# Altium schematic sheet 884-SG313_Potting_Controller.SchDoc
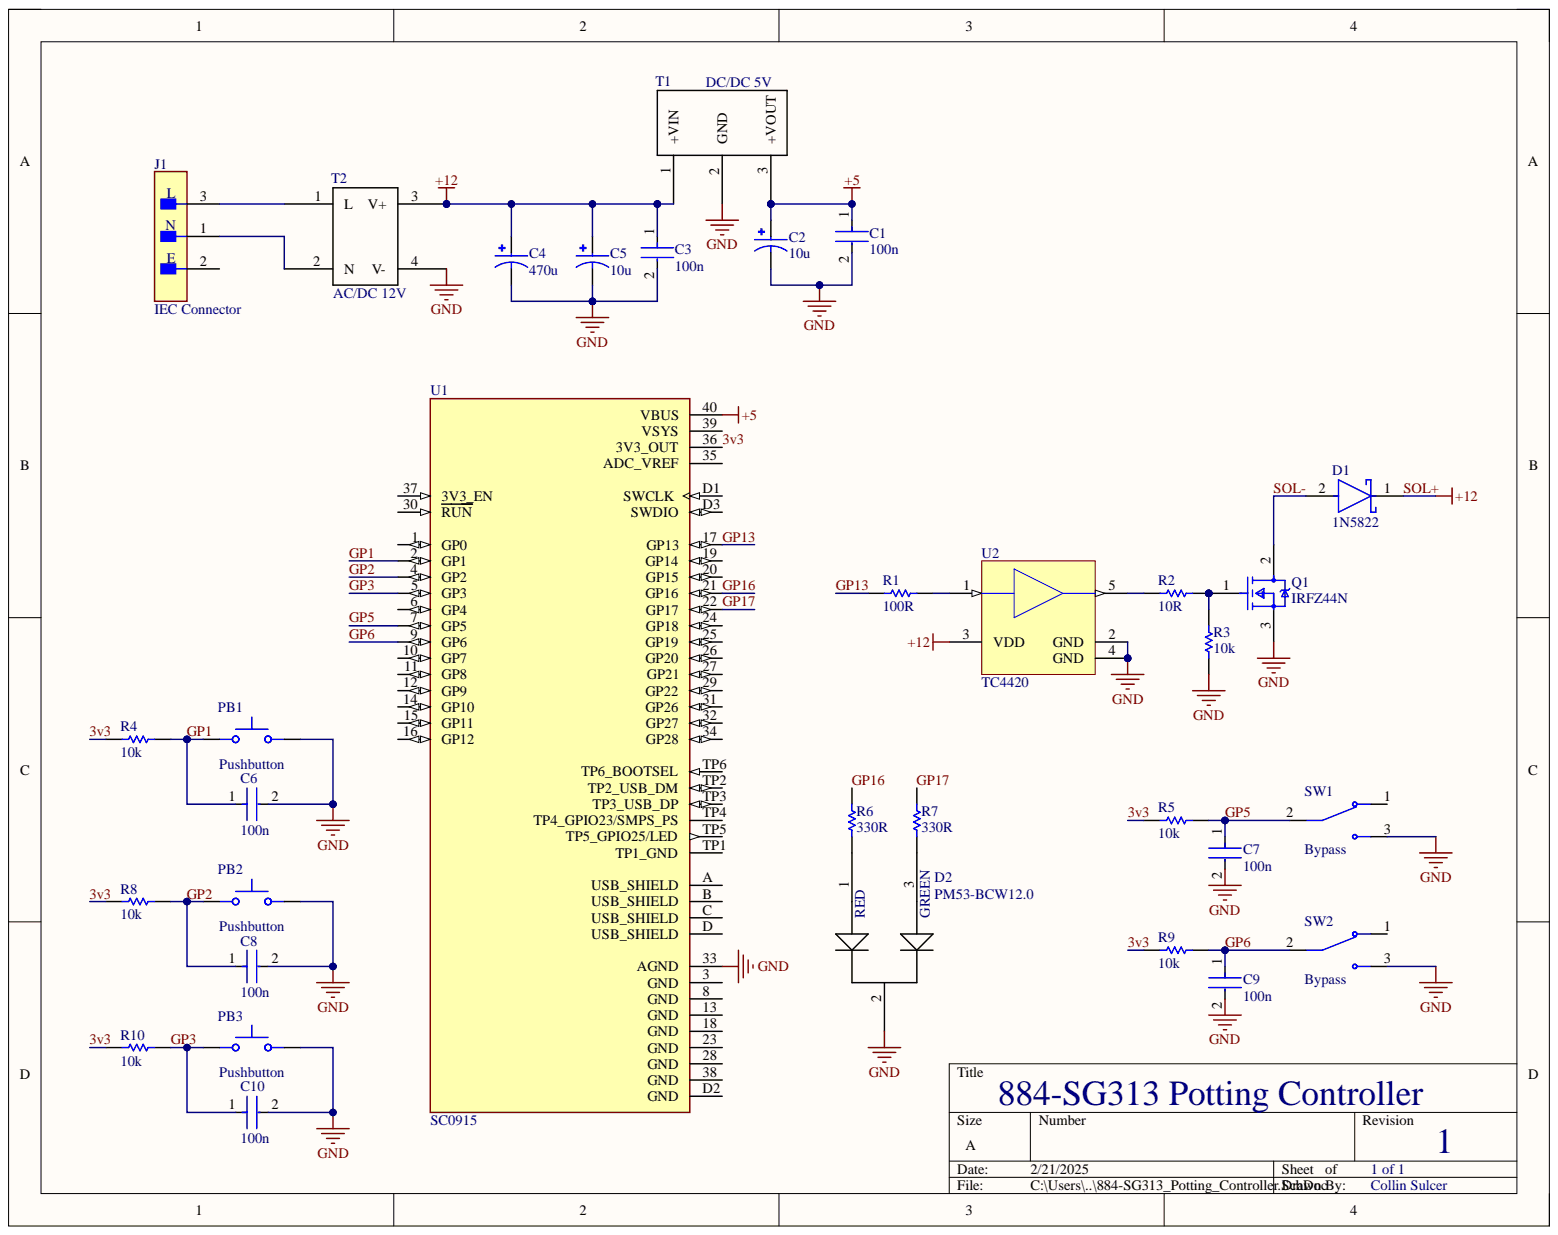
[diagram p0: 884-SG313_Potting_Controller.SchDoc]

## Parts
C1  100n  [FP-RDE1P1-MFG]
C2  10u  [CAPPRD500W45D630H500]
C3  100n  [FP-RDE1P1-MFG]
C4  470u  [CAPPRD500W45D630H500]
C5  10u  [CAPPRD500W45D630H500]
C6  100n  [FP-RDE1P1-MFG]
C7  100n  [FP-RDE1P1-MFG]
C8  100n  [FP-RDE1P1-MFG]
C9  100n  [FP-RDE1P1-MFG]
C10  100n  [FP-RDE1P1-MFG]
D1  1N5822  [FP-DO-201AD-MFG]
D2  PM53-BCW12.0
J1  IEC Connector  [FP-703W-00-52-MFG]
PB1  Pushbutton  [SW_800AWSP9M2QE]
PB2  Pushbutton  [SW_800AWSP9M2QE]
PB3  Pushbutton  [SW_800AWSP9M2QE]
Q1  IRFZ44N  [FP-PG-TO262-3-MFG]
R1  100R  [RESA60-630X240]
R2  10R  [RESA60-630X240]
R3  10k  [RESA60-630X240]
R4  10k  [RESA60-630X240]
R5  10k  [RESA60-630X240]
R6  330R  [RESA60-630X240]
R7  330R  [RESA60-630X240]
R8  10k  [RESA60-630X240]
R9  10k  [RESA60-630X240]
R10  10k  [RESA60-630X240]
SW1  Bypass  [SW_100SP3T1B1M2QE]
SW2  Bypass  [SW_100SP3T1B1M2QE]
T1  DC/DC 5V  Max Output Voltage: 5V
T2  AC/DC 12V  Voltage - Output 1: 12V
U1  SC0915  [MODULE_SC0915]
U2  TC4420  [TO-220-AT5]

## Nets
+5: C1.1 C2.P T1.3 U1.40(VBUS)
GND: C1.2 C2.N C3.2 C4.N C5.N C6.2 C7.2 C8.2 C9.2 C10.2 D2.2 PB1.3 PB2.3 PB3.3 Q1.3 R3.1 SW1.3 SW2.3 T1.2 T2.4(V-) U1.33(AGND) U2.2(GND) U2.4(GND)
3v3: R4.1 R5.1 R8.1 R9.1 R10.1 U1.36(3V3_OUT)
GP1: C6.1 PB1.1 R4.2 U1.2(GP1)
GP2: C8.1 PB2.1 R8.2 U1.4(GP2)
GP3: C10.1 PB3.1 R10.2 U1.5(GP3)
GP5: C7.1 R5.2 SW1.2 U1.7(GP5)
GP6: C9.1 R9.2 SW2.2 U1.9(GP6)
GP13: R1.1 U1.17(GP13)
GP16: R6.2 U1.21(GP16)
GP17: R7.2 U1.22(GP17)
SOL+: C3.1 C4.P C5.P D1.1 T1.1 T2.3(V+) U2.3(VDD)
SOL-: D1.2 Q1.2
NetD2_1: D2.1 R6.1
NetD2_3: D2.3 R7.1
NetJ1_1: J1.1 T2.2(N)
NetJ1_3: J1.3 T2.1(L)
NetQ1_1: Q1.1 R2.2 R3.2
NetR1_2: R1.2 U2.1
NetR2_1: R2.1 U2.5

Not connected: J1 2; SW1 1; SW2 1; U1 1(GP0) 3(GND) 6(GP4) 8(GND) 10(GP7) 11(GP8) 12(GP9) 13(GND) 14(GP10) 15(GP11) 16(GP12) 18(GND) 19(GP14) 20(GP15) 23(GND) 24(GP18) 25(GP19) 26(GP20) 27(GP21) 28(GND) 29(GP22) 30(RUN) 31(GP26) 32(GP27) 34(GP28) 35(ADC_VREF) 37(3V3_EN) 38(GND) 39(VSYS) A(USB_SHIELD) B(USB_SHIELD) C(USB_SHIELD) D(USB_SHIELD) D1(SWCLK) D2(GND) D3(SWDIO) TP1(TP1_GND) TP2(TP2_USB_DM) TP3(TP3_USB_DP) TP4(TP4_GPIO23/SMPS_PS) TP5(TP5_GPIO25/LED) TP6(TP6_BOOTSEL)

## Library data of the parts
C1 C3 C6-C10 = CMP-00029-1: Cap Ceramic 0.1uF 100V X7R 10% Radial 2.5mm 125°C Ammo Pack; Lead Diameter: 0.5mm; Lead/Base Style: Formed Leads; Value: 100nF; Case/Package: Radial; Component Kind: Standard; Dielectric: X7R; Height: 3.5mm; Height - Seated (Max): 0.197inch; Lead Free: Lead Free; Lead Pitch: 0.098inch; Length: 0.177inch; Max Operating Temperature: 125°C; Min Operating Temperature: -55°C; Mounting Technology: Through Hole; Package Quantity: 1; Packaging: Cut Tape; RoHS Compliant: Yes; Series: RDE; Termination: Radial; Tolerance: 10%; Voltage Rating: 100V; Voltage Rating (DC): 100V; Width: 0.124inch; Library Name: Sensit_Workspace
C2 C4 C5 = CMP-024-000000-3: CAP ALUM 10UF 20% 50V RADIAL; MF: Panasonic; MP: ECE-A1HKS100B; Availability: In Stock; Check_prices: https://www.snapeda.com/parts/ECE-A1HKS100B/Panasonic/view-part/2307567/?ref=eda; Component Kind: Standard; Component Type: Standard; Design Item ID: ECEA1HKS100B; Package: RADIAL-2 Panasonic; Pin Count: 2; Price: None; Purchase-URL: https://pricing.snapeda.com/search/part/ECE-A1HKS100B/?ref=eda; SnapEDA_Link: https://www.snapeda.com/parts/ECE-A1HKS100B/Panasonic/view-part/2307567/?ref=snap; Source: ECEA1HKS100B.SchLib; Library Name: Sensit_Workspace
D1 = CMP-00044-1: Rectifier Diode Schottky 40V 3A 2-Pin DO-201AD T/R / DIODE SCHOTTKY 40V 3A DO201AD; Forward Voltage: 525mV; Max Forward Surge Current (Ifsm): 80A; Max Reverse Current: 500uA; Max Reverse Leakage Current: 2mA; Polarity: Standard; Value: 190pF; Average Rectified Current: 3A; Case/Package: DO-201AD; Component Kind: Standard; Current Rating: 3A; Forward Current: 3A; Height: 5.3mm; Lead Free: Lead Free; Length: 9.5mm; Max Operating Temperature: 150°C; Max Repetitive Reverse Voltage (Vrrm): 40V; Max Reverse Voltage (DC): 40V; Max Surge Current: 80A; Min Operating Temperature: -65°C; Mounting Technology: Through Hole; Output Current: 3A; Package Quantity: 1; Packaging: Cut Tape; Pins: 2; Reach SVHC Compliant: No; RoHS Compliant: Yes; Series: -; Voltage Rating (DC): 40V; Width: 5.3mm; Library Name: Sensit_Workspace
D2 = CMP-00045-6: Panel Mount Led Assembly 3 Leaded 5mm Red/Green w/ White Diffused Connector; Color: Green,Red; Current: 30mA; Forward Current: 20mA; Forward Voltage: 2.1V; Luminous Intensity: 35mcd; Viewing Angle: 40°; Voltage: 2V; Wavelength: 568nm; Component Kind: Standard; Illumination Color: Green,Red; Lead Free: Lead Free; Lens Color: White; Lens Style: Diffused; Lens Transparency: Diffused; Package Quantity: 100; Reach SVHC Compliant: No; Series: PM53; Termination: Wire Leads; Library Name: Sensit_Workspace
J1 = CMP-00046-1: Mains Power Connector, 15A, 250VAC, Female, Solder Terminal, Receptacle; Application(s): AC; Approvals: UL,CSA,VDE,NEMKO,FIMKO,SEMKO,DEMKO,KEMA; Fuse: No; Max Voltage Rating (AC): 250V; Number of Contacts: 3; Number of Poles: 2; Pitch: 7mm; Color: Black; Component Kind: Standard; Connector Type: Receptacle; Contact Plating: Tin; Contact Type: Pin; Current: 15A; Current Rating: 15A; Gender: Receptacle; Housing Material: Thermoplastic; Lead Free: Lead Free; Max Current Rating: 15A; Mounting Technology: Through Hole,Panel,Flanges,PCB; Number of Positions: 3; Orientation: Right Angle; Package Quantity: 100; Primary Type: POWER; Reach SVHC Compliant: No; RoHS Compliant: Yes; Series: 703W; Termination: Solder; Voltage Rating: 250V; Voltage Rating (AC): 250V; Voltage Rating (DC): 250V; Library Name: Sensit_Workspace
PB1-PB3 = CMP-085-000000-1: Pushbutton Switch SPST-NO Standard Through Hole; MF: E-Switch; MP: 800AWSP9M2QE; Availability: In Stock; Check_prices: https://www.snapeda.com/parts/800AWSP9M2QE/E-Switch/view-part/?ref=eda; Component Kind: Standard; Component Type: Standard; Design Item ID: 800AWSP9M2QE; Package: None; Pin Count: 2; Price: None; Purchase-URL: https://pricing.snapeda.com/search/part/800AWSP9M2QE/?ref=eda; SnapEDA_Link: https://www.snapeda.com/parts/800AWSP9M2QE/E-Switch/view-part/?ref=snap; Source: 800AWSP9M2QE.SchLib; Library Name: Sensit_Workspace
Q1 = CMP-000008-1: Single N-Channel 55 V 17.5 mOhm 63 nC HEXFET® Power Mosfet - TO-262; Component Kind: Standard; Library Name: Sensit_Workspace
R1-R10 = CMP-00030-1: Fixed Resistor, Metal Film, 0.25W, 1000ohm, 250V, 1% +/-Tol, 100ppm/Cel, Through Hole Mount; Lead Diameter: 0.6mm; Max Power Dissipation: 250mW; Temperature Coefficient: 100ppm/°C; Value: 1kR; Voltage Rating (DC): 200V; Case/Package: Axial; Component Kind: Standard; Lead Free: Lead Free; Length: 0.248inch; Max Operating Temperature: 155°C; Min Operating Temperature: -55°C; Mounting Technology: Through Hole; Number of Terminations: 2; Package Quantity: 200; Packaging: Bulk; Power Rating: 250mW; RoHS Compliant: Yes; Series: MFR; Termination: Axial; Tolerance: 1%; Voltage Rating: 250V; Width: 6.3mm; Library Name: Sensit_Workspace
SW1 SW2 = CMP-072-000000-3: SWITCH TOGGLE DPDT 5A 120V; Actuator Length: 10.41mm; Actuator Material: Brass,Chrome; Actuator Type: Standard Round; Electrical Life: 40000Cycles; Illumination Type: Non-Illuminated; MF: {'manufacturer': u'E-Switch'}; MP: 100SP3T1B1M2QE; Switch Function: On-Off-On; Throw Configuration: SPDT; Voltage Rating (DC): 28V; Availability: Unavailable; Component Kind: Standard; Component Type: Standard; ComponentLink1Description: Digikey; ComponentLink1URL: https://www.digikey.com/en/products/detail/e-switch/100DP3T1B1M2QEH/378883?gclsrc=aw.ds&&utm_adgroup=Switches&utm_source=google&utm_medium=cpc&utm_campaign=Dynamic%20Search_EN_Product&utm_term=&utm_content=Switches&utm_id=go_cmp-120565755_adg-7526266155_ad-665604606650_dsa-138295968057_dev-c_ext-_prd-_sig-Cj0KCQiAvP-6BhDyARIsAJ3uv7ZmndSe9KIbKwHjj63Wh8Eudj0nY0ITsvF4Yv66PYI7WJaNtlyv6ZcaAq2rEALw_wcB&gad_source=1&gclid=Cj0KCQiAvP-6BhDyARIsAJ3uv7ZmndSe9KIbKwHjj63Wh8Eudj0nY0ITsvF4Yv66PYI7WJaNtlyv6ZcaAq2rEALw_wcB&gclsrc=aw.ds; Design Item ID: 100SP3T1B1M2QE; Max Operating Temperature: 85°C; Min Operating Temperature: -30°C; Mounting Technology: Panel; Package: None; Packaging: Bulk; Pin Count: 3; Price: None; RoHS Compliant: No; Series: 100; Source: 100SP3T1B1M2QE.SchLib; Termination: PC Pin; Voltage Rating (AC): 120V; Library Name: Sensit_Workspace
T1 = CMP-00047-2: 0.5A DC/DC-Converter 'INNOLINE' W SIP3 reg; V. In: 6.5-32; V. Out: 5.0; mA. Out: 500; Current - Output 1: 500mA; Efficiency: 93%; Max Supply Voltage: 32V; Min Input Voltage: 6.5V; Number of Outputs: 1; Output Power: 2.5W; Component Kind: Standard; Depth: 10.2mm; Height: 17.5mm; Lead Free: Lead Free; Length: 11.5mm; Max Operating Temperature: 85°C; Min Operating Temperature: -40°C; Mounting Technology: Chassis Mount,Through Hole; Output Current: 500mA; Output Voltage: 5V; Package Quantity: 25; Packaging: Bulk; Series: INNOLINE R-78-0.5; Voltage - Output 1: 5V; Weight: 4.8g; Width: 8.5mm; Library Name: Sensit_Workspace
T2 = CMP-00048-2: Switching Power Supplies AC-DC Open Frame, 6 Watt, Single Output,; Efficiency: 81%; Isolation Voltage: 3kV; Max Output current: 500mA; Max Power Dissipation: 6W; Number of Outputs: 1; Component Kind: Standard; Max Operating Temperature: 80°C; Min Operating Temperature: -40°C; Mounting Technology: Chassis Mount; RoHS Compliant: Yes; Series: CFM06S (6W); Library Name: Sensit_Workspace
U1 = CMP-083-000000-1: RP2040 Raspberry Pi Pico series ARMÂ® CortexÂ®-M0+ MCU 32-Bit Embedded Evaluation Board; Availability: In Stock; Check_prices: https://www.snapeda.com/parts/SC0915/Raspberry+Pi/view-part/?ref=eda; Component Kind: Standard; Component Type: Standard; Design Item ID: SC0915; MF: Raspberry Pi; MP: SC0915; Package: None; Pin Count: 53; Price: None; Purchase-URL: https://pricing.snapeda.com/search/part/SC0915/?ref=eda; SnapEDA_Link: https://www.snapeda.com/parts/SC0915/Raspberry+Pi/view-part/?ref=snap; Source: SC0915.SchLib; Library Name: Sensit_Workspace
U2 = CMP-00040-1: Driver 6A 1-OUT Low Side Non-Inv Automotive 5-Pin(5+Tab) TO-220 Tube; Channel Type: Single; Fall Time: 35ns; Max Power Dissipation: 1.6W; Max Supply Current: 1.5mA; Max Supply Voltage: 18V; Min Supply Voltage: 4.5V; Number of Drivers: 1; Propagation Delay: 75ns; Supply Current: 3mA; Case/Package: TO-220-5; Component Kind: Standard; Lead Free: Lead Free; Max Operating Temperature: 70°C; Max Output current: 6A; Min Operating Temperature: 0°C; Mounting Technology: Through Hole; Number of Outputs: 1; Package Quantity: 50; Packaging: Rail/Tube; Power Dissipation: 1.6W; Rise Time: 35ns; RoHS Compliant: Yes; Series: -; Library Name: Sensit_Workspace
